# Altium schematic sheet P200621BV01-01.SchDoc
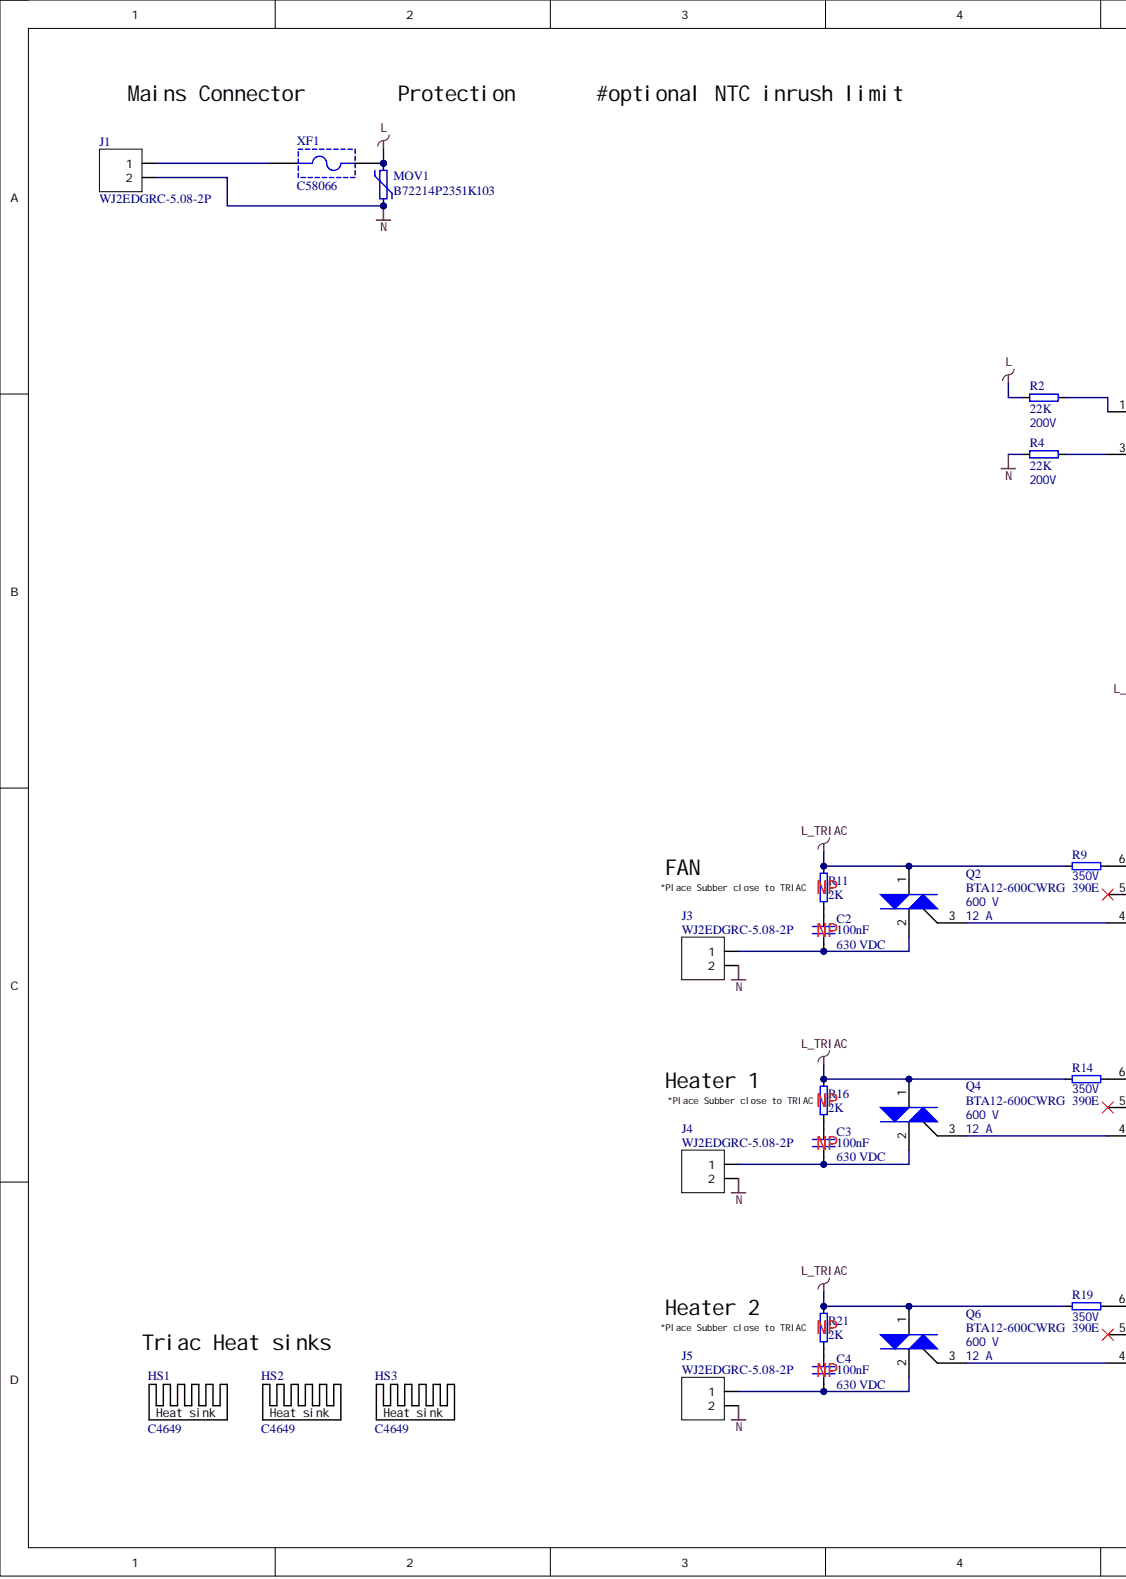
[diagram p0: P200621BV01-01.SchDoc, left]
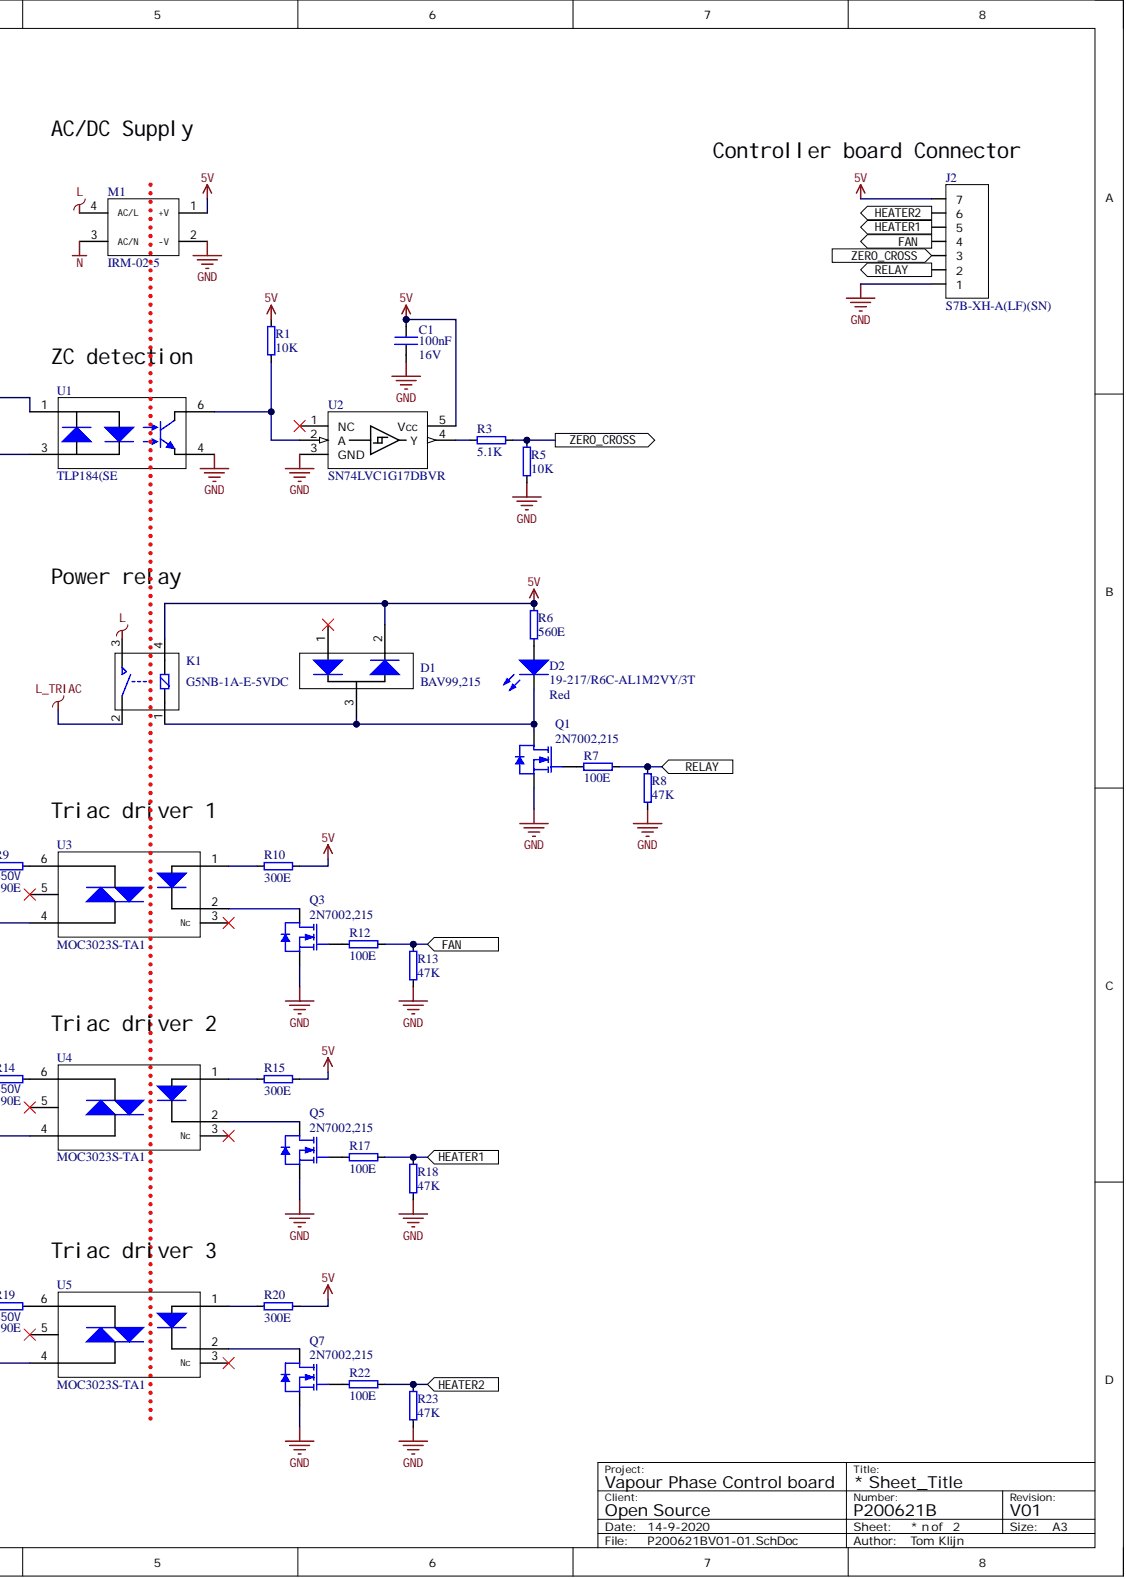
[diagram p1: P200621BV01-01.SchDoc, right]

## Parts
C1  100nF  Voltage: 16V  [CAP 0603 1608 IPC MEDIUM]
C2  100nF  [KP104J2J1006 - KYET]
C3  100nF  [KP104J2J1006 - KYET]
C4  100nF  [KP104J2J1006 - KYET]
D1  BAV99,215  [SOT23-3 IPC MEDIUM - NEXPERIA]
D2  19-217/R6C-AL1M2VY/3T  Colour: Red  [19-217 - EVERLIGHT]
HS1  C4649  [C4649 - XSD]
HS2  C4649  [C4649 - XSD]
HS3  C4649  [C4649 - XSD]
J1  WJ2EDGRC-5.08-2P  [691313510002 - WURTH]
J2  S7B-XH-A(LF)(SN)  [S7B-XH-A(LF)(SN) - JST]
J3  WJ2EDGRC-5.08-2P  [691313510002 - WURTH]
J4  WJ2EDGRC-5.08-2P  [691313510002 - WURTH]
J5  WJ2EDGRC-5.08-2P  [691313510002 - WURTH]
K1  G5NB-1A-E-5VDC  [G5NB - OMRON]
M1  IRM-02-5  [IRM-02-5 - MEAN WELL]
MOV1  B72214P2351K103  [B72214P2351K103 - EPCOS]
Q1  2N7002,215  [SOT23-3 IPC MEDIUM - NEXPERIA]
Q2  BTA12-600CWRG  IT(rms): 12 A  VDRM: 600 V  [TO-220AB - STMICROELECTRONICS]
Q3  2N7002,215  [SOT23-3 IPC MEDIUM - NEXPERIA]
Q4  BTA12-600CWRG  IT(rms): 12 A  VDRM: 600 V  [TO-220AB - STMICROELECTRONICS]
Q5  2N7002,215  [SOT23-3 IPC MEDIUM - NEXPERIA]
Q6  BTA12-600CWRG  IT(rms): 12 A  VDRM: 600 V  [TO-220AB - STMICROELECTRONICS]
Q7  2N7002,215  [SOT23-3 IPC MEDIUM - NEXPERIA]
R1  10K  [RES 0603 1608 IPC MEDIUM]
R2  22K  [RES 1206 3216 IPC MEDIUM]
R3  5.1K  [RES 0603 1608 IPC MEDIUM]
R4  22K  Voltage: 200V  [RES 1206 3216 IPC MEDIUM]
R5  10K  [RES 0603 1608 IPC MEDIUM]
R6  560E  [RES 0603 1608 IPC MEDIUM]
R7  100E  [RES 0603 1608 IPC MEDIUM]
R8  47K  [RES 0603 1608 IPC MEDIUM]
R9  390E  Voltage: 350V  [RN1 2W390FT BA1 - TYOHM]
R10  300E  [RES 0603 1608 IPC MEDIUM]
R11  2K  [RN1WS1.8KFT BA1 - TYOHM]
R12  100E  [RES 0603 1608 IPC MEDIUM]
R13  47K  [RES 0603 1608 IPC MEDIUM]
R14  390E  Voltage: 350V  [RN1 2W390FT BA1 - TYOHM]
R15  300E  [RES 0603 1608 IPC MEDIUM]
R16  2K  [RN1WS1.8KFT BA1 - TYOHM]
R17  100E  [RES 0603 1608 IPC MEDIUM]
R18  47K  [RES 0603 1608 IPC MEDIUM]
R19  390E  Voltage: 350V  [RN1 2W390FT BA1 - TYOHM]
R20  300E  [RES 0603 1608 IPC MEDIUM]
R21  2K  [RN1WS1.8KFT BA1 - TYOHM]
R22  100E  [RES 0603 1608 IPC MEDIUM]
R23  47K  [RES 0603 1608 IPC MEDIUM]
U1  TLP184(SE  [11-4M1S IPC MED - TOSHIBA]
U2  SN74LVC1G17DBVR  [SOT23-5 IPC MEDIUM - TEXAS INSTRUMENTS]
U3  MOC3023S-TA1  [MOC302X SOP-6 - IPC MEDIUM - LITE-ON]
U4  MOC3023S-TA1  [MOC302X SOP-6 - IPC MEDIUM - LITE-ON]
U5  MOC3023S-TA1  [MOC302X SOP-6 - IPC MEDIUM - LITE-ON]
XF1  C58066  [696101000002 - WURTH]

## Ports
FAN, HEATER1, HEATER2, RELAY, ZERO_CROSS

## Nets
5V: C1.1 D1.2 J2.7 K1.4 M1.1(+V) R1.1 R6.1 R10.2 R15.2 R20.2 U2.5(Vcc)
GND: C1.2 J2.1 M1.2(-V) Q1.2 Q3.2 Q5.2 Q7.2 R5.2 R8.2 R13.2 R18.2 R23.2 U1.4 U2.3(GND)
L: K1.3 M1.4(AC/L) MOV1.2 R2.1 XF1.2
L_TRIAC: K1.2 Q2.1 Q4.1 Q6.1 R9.1 R11.1 R14.1 R16.1 R19.1 R21.1
N: J1.2 J3.2 J4.2 J5.2 M1.3(AC/N) MOV1.1 R4.1
FAN: J2.4 R12.1 R13.1 ; port FAN
HEATER1: J2.5 R17.1 R18.1 ; port HEATER1
HEATER2: J2.6 R22.1 R23.1 ; port HEATER2
RELAY: J2.2 R7.1 R8.1 ; port RELAY
ZERO_CROSS: J2.3 R3.2 R5.1 ; port ZERO_CROSS
NetC2_1: C2.1 R11.2
NetC2_2: C2.2 J3.1 Q2.2
NetC3_1: C3.1 R16.2
NetC3_2: C3.2 J4.1 Q4.2
NetC4_1: C4.1 R21.2
NetC4_2: C4.2 J5.1 Q6.2
NetD1_3: D1.3 D2.2 K1.1 Q1.3
NetD2_1: D2.1 R6.2
NetJ1_1: J1.1 XF1.1
NetQ1_1: Q1.1 R7.2
NetQ2_3: Q2.3 U3.4
NetQ3_1: Q3.1 R12.2
NetQ3_3: Q3.3 U3.2
NetQ4_3: Q4.3 U4.4
NetQ5_1: Q5.1 R17.2
NetQ5_3: Q5.3 U4.2
NetQ6_3: Q6.3 U5.4
NetQ7_1: Q7.1 R22.2
NetQ7_3: Q7.3 U5.2
NetR1_2: R1.2 U1.6 U2.2(A)
NetR2_2: R2.2 U1.1
NetR3_1: R3.1 U2.4(Y)
NetR4_2: R4.2 U1.3
NetR9_2: R9.2 U3.6
NetR10_1: R10.1 U3.1
NetR14_2: R14.2 U4.6
NetR15_1: R15.1 U4.1
NetR19_2: R19.2 U5.6
NetR20_1: R20.1 U5.1

Not connected: D1 1; U2 1(NC); U3 3(Nc) 5; U4 3(Nc) 5; U5 3(Nc) 5

## Library data of the parts
C1 = 62: Generic 0603 1608 Capacitor; Manufacturer: KEMET; Part Number: C0603C104M4RACTU; Case Technology: SMT; Database Table Name: Capacitors - 0603 1608$; Dielectric: X7R; Head IPN: 051196; Max Temperature: 125°C; Tolerance: 20%; Capacitance: 100nF; Component Kind: Standard; ComponentLink1Description: Octopart Search; ComponentLink1URL: http://octopart.com/search?q=C0603C104M4RACTU%20KEMET; DB_ID: 62; Library Name: PartsDb.DbLib; Supplier 1: Farnell; Supplier 2: -; Supplier Part Number 1: 2581045
C2-C4 = 1: 100nF ±5% 630V 10 -40℃ ~ +100℃ Through Hole,P=10mm CBB Capacitors(polypropylene film) RoHS; Manufacturer: KYET; Part Number: KP104J2J1006; Case Technology: THT; ComponentLink2Filename: KP104J2J1006 - Datasheet - KYET; Database Table Name: Capacitors - Film Capacitors$; Dielectric: Polypropylene (PP); Voltage: 630 VDC; Capacitance: 100nF; Component Kind: Standard; ComponentLink1Description: Octopart Search; ComponentLink1URL: http://octopart.com/search?q=KP104J2J1006%20KYET; ComponentLink2Description: Datasheet; DB_ID: 1; Library Name: PartsDb.DbLib; Supplier 1: LCSC; Supplier 2: -; Supplier 3: -; Supplier 4: -; Supplier Part Number 1: C390130; Tolerance: 5 %; ComponentLink2URL: C:\Users\Admin\stack\Altium\Database Library Tom\Datasheets\KP104J2J1006 - Datasheet - KYET.pdf
D1 = 3: Dual series high-speed switching diodes, encapsulated in a small SOT23; Manufacturer: NEXPERIA; Case Technology: SMT; ComponentLink2Filename: BAV99,215 - Datasheet - NEXPERIA; Database Table Name: Diodes$; If: 215 mA; VF Max: 1.25 V; Vrrm Max: 100 V; Component Kind: Standard; ComponentLink1Description: Octopart Search; ComponentLink1URL: http://octopart.com/search?q=BAV99,215%20NEXPERIA; ComponentLink2Description: Datasheet; ComponentLink3Description: Product page; ComponentLink3URL: https://www.nexperia.com/products/diodes/switching-diodes/general-purpose-switching-diodes/BAV99.html; DB_ID: 3; Diode Configuration: Dual; Library Name: PartsDb.DbLib; Part Number: BAV99,215; Supplier 1: Farnell; Supplier 2: Mouser; Supplier 3: LCSC; Supplier 4: -; Supplier Part Number 1: 1081211; Supplier Part Number 2: 771-BAV99-T/R; Supplier Part Number 3: C2500; ComponentLink2URL: C:\Users\Admin\stack\Altium\Database Library Tom\Datasheets\BAV99,215 - Datasheet - NEXPERIA.pdf
D2 = 11: Red 617.5~633.5nm 0603 Light Emitting Diodes (LED) RoHS; Manufacturer: EVERLIGHT; Case Technology: SMT; ComponentLink2Filename: 19-217 R6C-AL1M2VY 3T - Datasheet - EVERLIGHT; Database Table Name: Diodes - LEDs$; If: 5 mA; Vf: 2.2 V; Wavelenght: 632 nm; Component Kind: Standard; ComponentLink1Description: Octopart Search; ComponentLink1URL: http://octopart.com/search?q=19-217/R6C-AL1M2VY/3T%20EVERLIGHT; ComponentLink2Description: Datasheet; ComponentLink3Description: Product page; ComponentLink3URL: https://www.everlight.com/SeriationProduct.aspx?Seq=ab6bdd5e-2405-e411-8b3b-0002a54e500f; DB_ID: 11; Library Name: PartsDb.DbLib; Part Number: 19-217/R6C-AL1M2VY/3T; Supplier 1: LCSC; Supplier 2: -; Supplier Part Number 1: C72044; ComponentLink2URL: C:\Users\Admin\stack\Altium\Database Library Tom\Datasheets\19-217 R6C-AL1M2VY 3T - Datasheet - EVERLIGHT.pdf
HS1-HS3 = 1: 15*10.5*21mm Heat Sinks aluminum; Manufacturer: XSD; Case Technology: THT; ComponentLink2Filename: C4649 - Datasheet - XSD; Database Table Name: Heat Sinks$; Component Kind: Standard; ComponentLink1Description: Octopart Search; ComponentLink1URL: http://octopart.com/search?q=C4649%20XSD; ComponentLink2Description: Datasheet; DB_ID: 1; Height: 21 mm; Length: 15.5 mm; Library Name: PartsDb.DbLib; Part Number: C4649; Supplier 1: LCSC; Supplier Part Number 1: C4649; Width: 10.5 mm; ComponentLink2URL: C:\Users\Admin\stack\Altium\Database Library Tom\Datasheets\C4649 - Datasheet - XSD.pdf
J1 J3-J5 = 45: 5.08 mm Horizontal PCB Header; Manufacturer: NINGBO KANGNEX ELEC; Case Technology: THT; ComponentLink2Filename: WJ2EDGRC-5.08-2P - Datasheet - NINGBO KANGNEX ELEC; Database Table Name: Connectors$; Pitch: 2.54 mm; Row count: 1; Component Kind: Standard; ComponentLink1Description: Octopart Search; ComponentLink1URL: http://octopart.com/search?q=WJ2EDGRC-5.08-2P%20NINGBO KANGNEX ELEC; ComponentLink2Description: Datasheet; ComponentLink3Description: Product page; ComponentLink3URL: http://china-kn.com/product/89-55.html; DB_ID: 45; Library Name: PartsDb.DbLib; Orientation: Horizontal; Part Number: WJ2EDGRC-5.08-2P; Pin count: 2; Subcategory: Wire-To-Board; Supplier 1: LCSC; Supplier 2: -; Supplier 3: -; Supplier Part Number 1: C3697; ComponentLink2URL: C:\Users\Admin\stack\Altium\Database Library Tom\Datasheets\WJ2EDGRC-5.08-2P - Datasheet - NINGBO KANGNEX ELEC.pdf
J2 = 50: Connector Header, XH Series, 7 Position, 2.5mm, Through Hole, Right Angle; Manufacturer: JST; Case Technology: THT; ComponentLink2Filename: S7B-XH-A(LF)(SN) - Datasheet - JST; Database Table Name: Connectors$; Pitch: 2.50 mm; Row count: 1; Component Kind: Standard; ComponentLink1Description: Octopart Search; ComponentLink1URL: http://octopart.com/search?q=S7B-XH-A(LF)(SN)%20JST; ComponentLink2Description: Datasheet; ComponentLink3Description: Product page; ComponentLink3URL: http://www.jst-mfg.com/product/detail_e.php?series=277; DB_ID: 50; Library Name: PartsDb.DbLib; Orientation: Horizontal; Part Number: S7B-XH-A(LF)(SN); Pin count: 7; Subcategory: Wire-To-Board; Supplier 1: Farnell; Supplier 2: Digikey; Supplier 3: LCSC; Supplier Part Number 1: 1516295; Supplier Part Number 2: 455-2240-ND; Supplier Part Number 3: C161875; ComponentLink2URL: C:\Users\Admin\stack\Altium\Database Library Tom\Datasheets\S7B-XH-A(LF)(SN) - Datasheet - JST.pdf
K1 = 3: General Purpose Relays SPST-NO Flux-Tight 5VDC High-Capacity; Manufacturer: OMRON; Case Technology: THT; Coil Resistance: 125 E; ComponentLink2Filename: G5NB-1A-E-5VDC - Datasheet - OMRON; Database Table Name: Relays$; Switching Current Max: 5A@AC; Switching Voltage Max: 250 VAC 30 VDC; Coil Voltage: 5 VDC; Component Kind: Standard; ComponentLink1Description: Octopart Search; ComponentLink1URL: http://octopart.com/search?q=G5NB-1A-E-5VDC%20OMRON; ComponentLink2Description: Datasheet; ComponentLink3Description: Product page; ComponentLink3URL: https://www.components.omron.com/product-detail?partId=375&modelFilter=true#partTableSelect#partTableSelect#partTableSelect; Contact Configuration: SPST-NO; DB_ID: 3; Library Name: PartsDb.DbLib; Part Number: G5NB-1A-E-5VDC; Supplier 1: Mouser; Supplier 2: LCSC; Supplier Part Number 1: 653-G5NB-1A-E-DC5; Supplier Part Number 2: C48746; ComponentLink2URL: C:\Users\Admin\stack\Altium\Database Library Tom\Datasheets\G5NB-1A-E-5VDC - Datasheet - OMRON.pdf
M1 = 13: AC/DC PCB Mount Power Supply (PSU), ITE, 1 Output, 2 W, 5 V, 400 mA; Manufacturer: MEAN WELL; Case Technology: THT; ComponentLink2Filename: IRM-02-5 - Datasheet - MEAN WELL; Database Table Name: Modules$; Vin Max: 305 VAC; Vin Min: 85 VAC; Component Kind: Standard; ComponentLink1Description: Octopart Search; ComponentLink1URL: http://octopart.com/search?q=IRM-02-5%20MEAN WELL; ComponentLink2Description: Datasheet; ComponentLink3Description: Product page; ComponentLink3URL: https://www.meanwell-web.com/en-gb/ac-dc-single-output-encapsulated-power-supply-pcb-irm--02--5; DB_ID: 13; Library Name: PartsDb.DbLib; Part Number: IRM-02-5; Subcategory: Power Supplies; Supplier 1: Farnell; Supplier 2: Mouser; Supplier 3: Digikey; Supplier 4: -; Supplier Part Number 1: 2815481; Supplier Part Number 2: 709-IRM02-5; Supplier Part Number 3: 1866-3009-ND; Vin Typ: -; ComponentLink2URL: C:\Users\Admin\stack\Altium\Database Library Tom\Datasheets\IRM-02-5 - Datasheet - MEAN WELL.pdf
MOV1 = 2: Varistors 460VDC 6kA 295pF 14mm Adv MP Compact; Manufacturer: EPCOS; Case Technology: THT; Clamping Voltage: 910 V; ComponentLink2Filename: B72214P2351K103 - Datasheet - EPCOS; Database Table Name: Varistors$; Varistor Voltage: 560 V; Voltage Rating AC: 350 VAC; Component Kind: Standard; ComponentLink1Description: Octopart Search; ComponentLink1URL: http://octopart.com/search?q=B72214P2351K103%20EPCOS; ComponentLink2Description: Datasheet; ComponentLink3Description: Product page; ComponentLink3URL: https://www.tdk-electronics.tdk.com/en/action/176014/-/530896/orderingCodeSearch.do?query=B72214P2351K103; DB_ID: 2; Diameter: 13.5 mm; Library Name: PartsDb.DbLib; Part Number: B72214P2351K103; Supplier 1: Mouser; Supplier 2: -; Supplier Part Number 1: 871-B72214P2351K103; Voltage Rating DC: 460 VDC; ComponentLink2URL: C:\Users\Admin\stack\Altium\Database Library Tom\Datasheets\B72214P2351K103 - Datasheet - EPCOS.pdf
Q1 Q3 Q5 Q7 = 6: Power MOSFET, N Channel, 60 V, 300 mA, 2.8 ohm, SOT-23; Manufacturer: NEXPERIA; Case Technology: SMT; ComponentLink2Filename: 2N7002,215 - Datasheet - NEXPERIA; Database Table Name: Transistors - MOSFET$; FET Type: N-Channel; Id: 300 mA; Pd: 830 mW; Rds(on): 5 E; Vds: 60 V; Vgs(th): 2.5 V; Component Kind: Standard; ComponentLink1Description: Octopart Search; ComponentLink1URL: http://octopart.com/search?q=2N7002,215%20NEXPERIA; ComponentLink2Description: Datasheet; ComponentLink3Description: Product page; ComponentLink3URL: https://www.nexperia.com/products/mosfets/small-signal-mosfets/2N7002.html; DB_ID: 6; Library Name: PartsDb.DbLib; Part Number: 2N7002,215; Subcategory: Single; Supplier 1: Farnell; Supplier 2: Mouser; Supplier 3: LCSC; Supplier Part Number 1: 1510761; Supplier Part Number 2: 771-2N7002-T/R; Supplier Part Number 3: C65189; ComponentLink2URL: C:\Users\Admin\stack\Altium\Database Library Tom\Datasheets\2N7002,215 - Datasheet - NEXPERIA.pdf
Q2 Q4 Q6 = 2: Triac Snubberless 12A 600V 35mA TO220AB, insulated pad; Manufacturer: STMICROELECTRONICS; ComponentLink2Filename: BTA12-600CWRG - Datasheet - STMICROELECTRONICS; Database Table Name: Thyristors - TRIACs$; Vgt: 1.3 V; Component Kind: Standard; ComponentLink1Description: Octopart Search; ComponentLink1URL: http://octopart.com/search?q=BTA12-600CWRG%20STMICROELECTRONICS; ComponentLink2Description: Datasheet; ComponentLink3Description: Product page; ComponentLink3URL: https://www.st.com/en/thyristors-scr-and-ac-switches/bta12.html; DB_ID: 2; Igt: 35 mA; Library Name: PartsDb.DbLib; Part Number: BTA12-600CWRG; Supplier 1: Mouser; Supplier 2: Digikey; Supplier 3: LCSC; Supplier Part Number 1: 511-BTA12-600CW; Supplier Part Number 2: 497-6667-5-ND; Supplier Part Number 3: C12092; ComponentLink2URL: C:\Users\Admin\stack\Altium\Database Library Tom\Datasheets\BTA12-600CWRG - Datasheet - STMICROELECTRONICS.pdf
R1 R5 = 99: HEAD default/prefered resistor; Manufacturer: VISHAY; Part Number: CRCW060310K0FKEA; Case Technology: SMT; Database Table Name: Resistors - 0603 1608$; Head IPN: 049889; Power: 100mW; Tolerance: 1%; Voltage: 75V; Component Kind: Standard; ComponentLink1Description: Octopart Search; ComponentLink1URL: http://octopart.com/search?q=CRCW060310K0FKEA%20VISHAY; DB_ID: 99; Library Name: PartsDb.DbLib; Resistance: 10K; Subcategory: Resistor E24 Range; Supplier 1: Farnell; Supplier 2: -; Supplier Part Number 1: 1469748
R2 = 107: HEAD default/prefered resistor; Part Number: x; Case Technology: SMT; Database Table Name: Resistors - 1206 3216$; Power: 250mW; Tolerance: 1%; Voltage: 200V; Component Kind: Standard; DB_ID: 107; Library Name: PartsDb.DbLib; Resistance: 22K; Subcategory: Resistor E24 Range; Supplier 1: -; Supplier 2: -; Supplier 3: -; Supplier 4: -
R3 = 92: HEAD default/prefered resistor; Part Number: x; Case Technology: SMT; Database Table Name: Resistors - 0603 1608$; Power: 100mW; Tolerance: 1%; Voltage: 50V; Component Kind: Standard; DB_ID: 92; Library Name: PartsDb.DbLib; Resistance: 5.1K; Subcategory: Resistor E24 Range; Supplier 1: -; Supplier 2: -
R4 = 107: HEAD default/prefered resistor; Part Number: x; Case Technology: SMT; Database Table Name: Resistors - 1206 3216$; Power: 250mW; Tolerance: 1%; Component Kind: Standard; DB_ID: 107; Library Name: PartsDb.DbLib; Resistance: 22K; Subcategory: Resistor E24 Range; Supplier 1: -; Supplier 2: -; Supplier 3: -; Supplier 4: -
R6 = 69: HEAD default/prefered resistor; Part Number: x; Case Technology: SMT; Database Table Name: Resistors - 0603 1608$; Power: 100mW; Tolerance: 1%; Voltage: 50V; Component Kind: Standard; DB_ID: 69; Library Name: PartsDb.DbLib; Resistance: 560E; Subcategory: Resistor E24 Range; Supplier 1: -; Supplier 2: -
R7 R12 R17 R22 = 51: HEAD default/prefered resistor; Manufacturer: VISHAY; Part Number: CRCW0603100RFKEA; Case Technology: SMT; Database Table Name: Resistors - 0603 1608$; Head IPN: 049766; Power: 100mW; Tolerance: 1%; Voltage: 75V; Component Kind: Standard; ComponentLink1Description: Octopart Search; ComponentLink1URL: http://octopart.com/search?q=CRCW0603100RFKEA%20VISHAY; DB_ID: 51; Library Name: PartsDb.DbLib; Resistance: 100E; Subcategory: Resistor E24 Range; Supplier 1: Farnell; Supplier 2: -; Supplier Part Number 1: 1469752
R8 R13 R18 R23 = 115: HEAD default/prefered resistor; Manufacturer: VISHAY; Part Number: CRCW060347K0JNEA; Case Technology: SMT; Database Table Name: Resistors - 0603 1608$; Head IPN: 057406; Power: 100mW; Tolerance: 1%; Voltage: 75V; Component Kind: Standard; ComponentLink1Description: Octopart Search; ComponentLink1URL: http://octopart.com/search?q=CRCW060347K0JNEA%20VISHAY; DB_ID: 115; Library Name: PartsDb.DbLib; Resistance: 47K; Subcategory: Resistor E24 Range; Supplier 1: Farnell; Supplier 2: -; Supplier Part Number 1: 1652881
R9 R14 R19 = 3: 390 ±1% 1/2W ±50ppm/°C Axial,3.3x9.2mm Metal Film Resistor; Manufacturer: TYOHM; Part Number: RN1/2W390FT/BA1; Case Technology: THT; ComponentLink2Filename: RN1 2W390FT BA1 - Datasheet - TYOHM; Database Table Name: Resistors - Power$; Power: 0.5W; Tolerance: 5%; Component Kind: Standard; ComponentLink1Description: Octopart Search; ComponentLink1URL: http://octopart.com/search?q=RN1/2W390FT/BA1%20TYOHM; ComponentLink2Description: Datasheet; ComponentLink3Description: Product page; ComponentLink3URL: http://www.tyohm.com.tw/product_d.php?lang=en&tb=1&id=10&cid=11; DB_ID: 3; Library Name: PartsDb.DbLib; Resistance: 390E; Supplier 1: LCSC; Supplier 2: -; Supplier 3: -; Supplier Part Number 1: C385626; ComponentLink2URL: C:\Users\Admin\stack\Altium\Database Library Tom\Datasheets\RN1 2W390FT BA1 - Datasheet - TYOHM.pdf
R10 R15 R20 = 62: HEAD default/prefered resistor; Part Number: x; Case Technology: SMT; Database Table Name: Resistors - 0603 1608$; Power: 100mW; Tolerance: 1%; Voltage: 50V; Component Kind: Standard; DB_ID: 62; Library Name: PartsDb.DbLib; Resistance: 300E; Subcategory: Resistor E24 Range; Supplier 1: -; Supplier 2: -
R11 R16 R21 = 2: 1.8K ±1% 1W ±50ppm/°C Axial,3.3x9.2mm Metal Film Resistor; Manufacturer: TYOHM; Part Number: RN1WS1.8KΩFT/BA1; Case Technology: THT; ComponentLink2Filename: RN1WS1.8KΩFT BA1 - Datasheet - TYOHM; Database Table Name: Resistors - Power$; Tolerance: 5%; Voltage: 500V; Component Kind: Standard; ComponentLink1Description: Octopart Search; ComponentLink1URL: http://octopart.com/search?q=RN1WS1.8KΩFT/BA1%20TYOHM; ComponentLink2Description: Datasheet; ComponentLink3Description: Product page; ComponentLink3URL: http://www.tyohm.com.tw/product_d.php?lang=en&tb=1&id=10&cid=10; DB_ID: 2; Library Name: PartsDb.DbLib; Power: 1W; Resistance: 2K; Supplier 1: LCSC; Supplier 2: -; Supplier 3: -; Supplier Part Number 1: C385265; ComponentLink2URL: C:\Users\Admin\stack\Altium\Database Library Tom\Datasheets\RN1WS1.8KΩFT BA1 - Datasheet - TYOHM.pdf
U1 = 63: Optocoupler, Transistor Output, 1 Channel, Surface Mount DIP, 4 Pins, 50 mA, 3.75 kV, 50 %; Manufacturer: TOSHIBA; Case Technology: SMT; ComponentLink2Filename: TLP184(SE - Datasheet - TOSHIBA; Database Table Name: ICs$; Component Kind: Standard; ComponentLink1Description: Octopart Search; ComponentLink1URL: http://octopart.com/search?q=TLP184(SE%20TOSHIBA; ComponentLink2Description: Datasheet; ComponentLink3Description: Product page; ComponentLink3URL: https://toshiba.semicon-storage.com/eu/semiconductor/product/optoelectronics/detail.TLP184%28SE.html; DB_ID: 63; Library Name: PartsDb.DbLib; Part Number: TLP184(SE; Subcategory: Optocouplers/Photocouplers; Supplier 1: Farnell; Supplier 2: Mouser; Supplier 3: LCSC; Supplier Part Number 1: 2524260; Supplier Part Number 2: 757-TLP184SE; Supplier Part Number 3: C393882; Vin max: -; Vin min: -; Vin typ: -; ComponentLink2URL: C:\Users\Admin\stack\Altium\Database Library Tom\Datasheets\TLP184(SE - Datasheet - TOSHIBA.pdf
U2 = 64: Schmitt-Trigger Buffer , 74LVC1G17, 1.65 V to 5.5 V, SOT-23-5; Manufacturer: TEXAS INSTRUMENTS; Case Technology: SMT; ComponentLink2Filename: SN74LVC1G17DBVE4 - Datasheet - TEXAS INSTRUMENTS; Database Table Name: ICs$; Vin max: 5.5 V; Vin min: 1.65 V; Component Kind: Standard; ComponentLink1Description: Octopart Search; ComponentLink1URL: http://octopart.com/search?q=SN74LVC1G17DBVR%20TEXAS INSTRUMENTS; ComponentLink2Description: Datasheet; ComponentLink3Description: Product page; ComponentLink3URL: https://www.ti.com/product/SN74LVC1G17; DB_ID: 64; Library Name: PartsDb.DbLib; Part Number: SN74LVC1G17DBVR; Subcategory: Buffers; Supplier 1: Farnell; Supplier 2: Mouser; Supplier 3: LCSC; Supplier Part Number 1: 3119606; Supplier Part Number 2: 595-SN74LVC1G17DBVR; Supplier Part Number 3: C7836; Vin typ: -; ComponentLink2URL: C:\Users\Admin\stack\Altium\Database Library Tom\Datasheets\SN74LVC1G17DBVE4 - Datasheet - TEXAS INSTRUMENTS.pdf
U3-U5 = 62: Optocoupler Triac AC-OUT 1-CH 400V 6-Pin PDIP SMT T/R; Manufacturer: LITE-ON; ComponentLink2Filename: MOC3023S-TA1 - Datasheet - LITE-ON; Database Table Name: ICs$; Case Technology: SMT; Component Kind: Standard; ComponentLink1Description: Octopart Search; ComponentLink1URL: http://octopart.com/search?q=MOC3023S-TA1%20LITE-ON; ComponentLink2Description: Datasheet; ComponentLink3Description: Product page; ComponentLink3URL: https://optoelectronics.liteon.com/en-global/Led/LED-Component/Detail/404/0/MOC3023; DB_ID: 62; Library Name: PartsDb.DbLib; Part Number: MOC3023S-TA1; Subcategory: Optocouplers/Photocouplers; Supplier 1: Mouser; Supplier 2: LCSC; Supplier 3: -; Supplier Part Number 1: 859-MOC3023S-TA1; Supplier Part Number 2: C115469; Vin max: -; Vin min: -; Vin typ: -; ComponentLink2URL: C:\Users\Admin\stack\Altium\Database Library Tom\Datasheets\MOC3023S-TA1 - Datasheet - LITE-ON.pdf
XF1 = 2: Fuse Holder, 250 V, 10 A, Cartridge Fuse Holder; Manufacturer: XUCHENG ELEC; Case Technology: THT; ComponentLink2Filename: C58066 - Datasheet - XUCHENG ELEC; Current Rating: 10 A; Database Table Name: Fuses$; Voltage Rating AC: 250 VAC; Component Kind: Standard; ComponentLink1Description: Octopart Search; ComponentLink1URL: http://octopart.com/search?q=C58066%20XUCHENG ELEC; ComponentLink2Description: Datasheet; DB_ID: 2; Fuse Type: Fuse holder; Library Name: PartsDb.DbLib; Part Number: C58066; Supplier 1: LCSC; Supplier 2: -; Supplier 3: -; Supplier Part Number 1: C3130; Voltage Rating DC: -; ComponentLink2URL: C:\Users\Admin\stack\Altium\Database Library Tom\Datasheets\C58066 - Datasheet - XUCHENG ELEC.pdf
